# Altium schematic sheet analog-to-digital.SchDoc
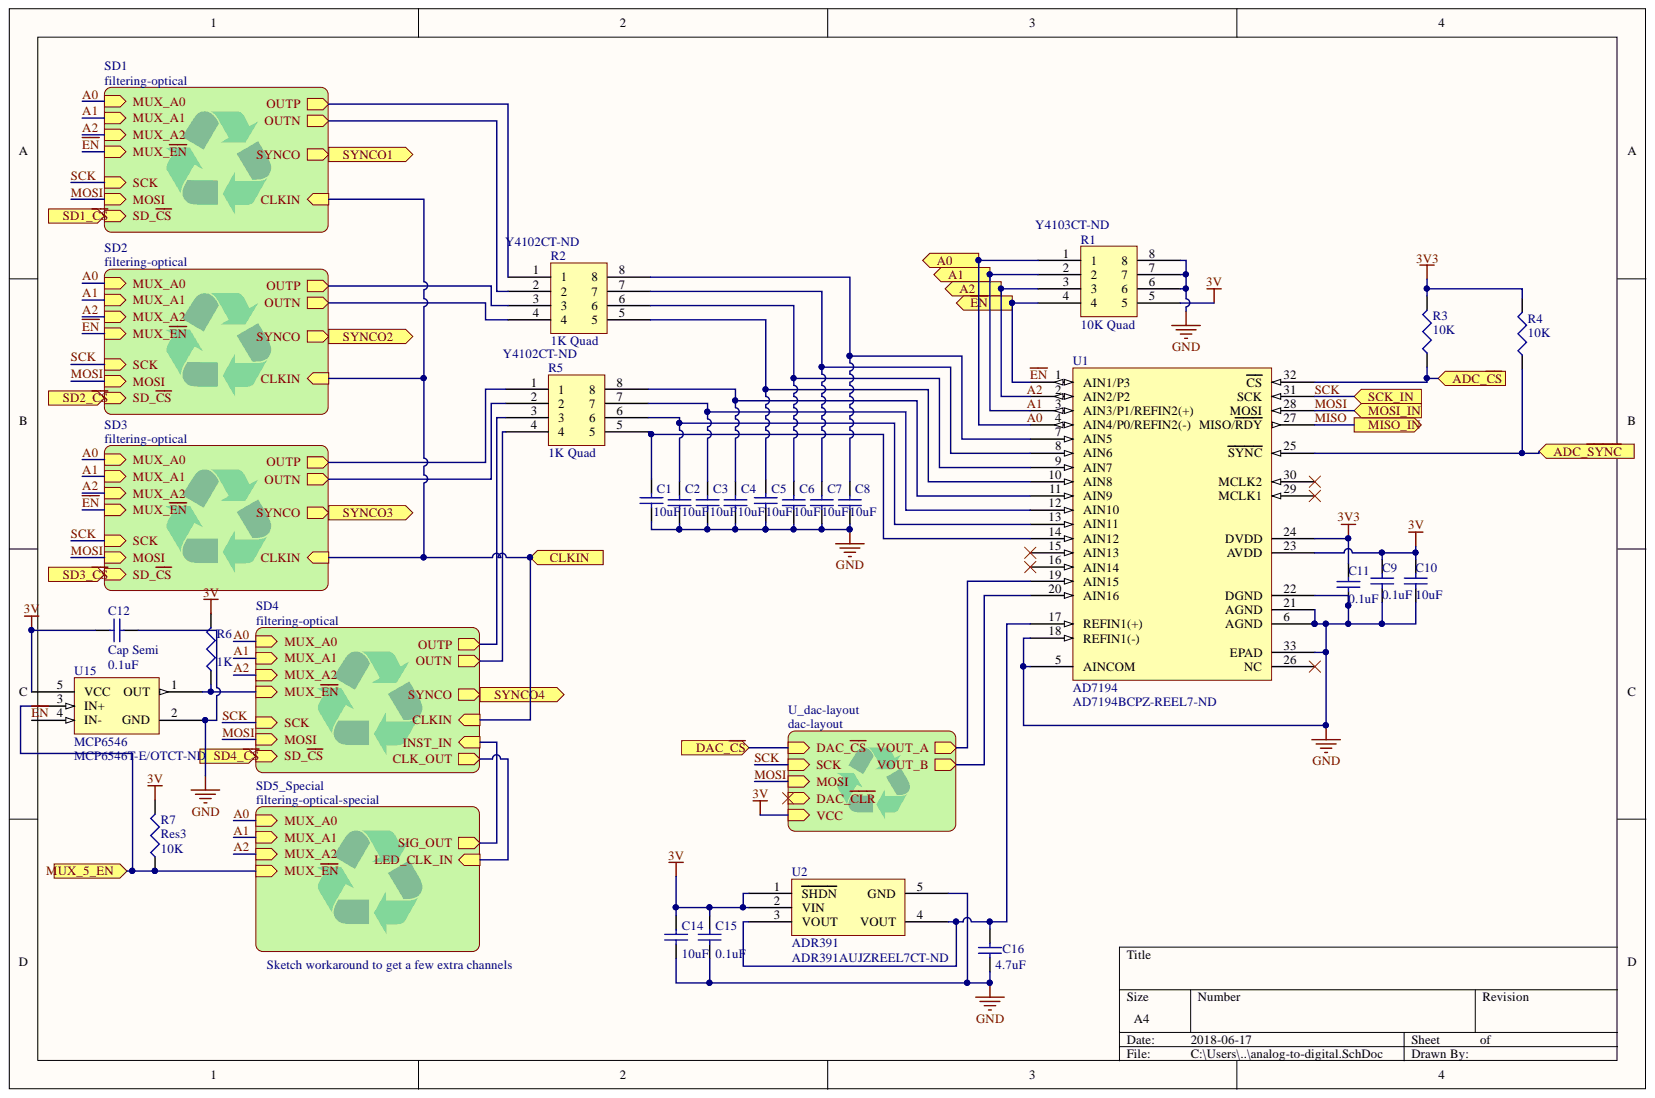
[diagram p0: analog-to-digital.SchDoc]

## Parts
C1  10uF  [1608[0603]]
C2  10uF  [1608[0603]]
C3  10uF  [1608[0603]]
C4  10uF  [1608[0603]]
C5  10uF  [1608[0603]]
C6  10uF  [1608[0603]]
C7  10uF  [1608[0603]]
C8  10uF  [1608[0603]]
C9  0.1uF  [0402]
C10  10uF  [1608[0603]]
C11  0.1uF  [0402]
C12  Cap Semi  value 0.1uF  [0402]
C14  10uF  [1608[0603]]
C15  0.1uF  [0402]
C16  4.7uF  [1608[0603]]
R1  10K Quad  Supplier Part Number: Y4103CT-ND  [ResQuad-320X150]
R2  1K Quad  Supplier Part Number: Y4102CT-ND  [ResQuad-320X150]
R3  10K  [0402]
R4  10K  [0402]
R5  1K Quad  Supplier Part Number: Y4102CT-ND  [ResQuad-320X150]
R6  value 1K  [0402]  (hidden comment: Res3)
R7  Res3  value 10K  [0402]
U1  AD7194  Supplier Part Number 1: AD7194BCPZ-REEL7-ND  [QFN50P500X500X100-32N]
U2  ADR391  Supplier Part Number 1: ADR391AUJZREEL7CT-ND  [SOT-23-5_95P290X160-5N]
U15  MCP6546  Supplier Part Number 1: MCP6546T-E/OTCT-ND  [SOT-23-5_95P290X160-5N]

## Ports
A0, A1, A2, ADC_~{CS}, ADC_~{SYNC}, CLKIN, DAC_~{CS}, MISO_IN, MOSI_IN, MUX_5_EN, SCK_IN, SD1_~{CS}, SD2_~{CS}, SD3_~{CS}, SD4_~{CS}, SYNCO1, SYNCO2, SYNCO3, SYNCO4, ~{EN}

## Nets
3V: C9.2 C10.2 C12.2 C14.2 C15.2 R1.5 R6.2 R7.2 U1.23(AVDD) U2.1(SHDN) U2.2(VIN) U15.5(VCC)
3V3: C11.2 R3.2 R4.2 U1.24(DVDD)
GND: C1.1 C2.1 C3.1 C4.1 C5.1 C6.1 C7.1 C8.1 C9.1 C10.1 C11.1 C12.1 C14.1 C15.1 C16.1 R1.6 R1.7 R1.8 U1.5(AINCOM) U1.6(AGND) U1.18(REFIN1(-)) U1.21(AGND) U1.22(DGND) U1.33(EPAD) U2.5(GND) U15.2(GND)
A0: R1.1 U1.4(AIN4/P0/REFIN2(-)) ; port A0
A1: R1.2 U1.3(AIN3/P1/REFIN2(+)) ; port A1
A2: R1.3 U1.2(AIN2/P2) ; port A2
ADC_~{CS}: R3.1 U1.32(CS) ; port ADC_~{CS}
ADC_~{SYNC}: R4.1 U1.25(SYNC) ; port ADC_~{SYNC}
~{EN}: R1.4 U1.1(AIN1/P3) U15.4(IN-) ; port ~{EN}
MISO: U1.27(MISO/RDY) ; port MISO_IN
MOSI: U1.28(MOSI) ; port MOSI_IN
MUX_5_EN: R7.1 U15.3(IN+) ; port MUX_5_EN
SCK: U1.31(SCK) ; port SCK_IN
NetC1_2: C1.2 R5.5 U1.14(AIN12)
NetC2_2: C2.2 R5.6 U1.13(AIN11)
NetC3_2: C3.2 R5.7 U1.12(AIN10)
NetC4_2: C4.2 R5.8 U1.11(AIN9)
NetC5_2: C5.2 R2.5 U1.10(AIN8)
NetC6_2: C6.2 R2.6 U1.9(AIN7)
NetC7_2: C7.2 R2.7 U1.8(AIN6)
NetC8_2: C8.2 R2.8 U1.7(AIN5)
NetC16_2: C16.2 U1.17(REFIN1(+)) U2.3(VOUT) U2.4(VOUT)
NetR2_1: R2.1
NetR2_2: R2.2
NetR2_3: R2.3
NetR2_4: R2.4
NetR5_1: R5.1
NetR5_2: R5.2
NetR5_3: R5.3
NetR5_4: R5.4
NetR6_1: R6.1 U15.1(OUT)
N00111:
N00112: ; port DAC_~{CS}
N00113:
N00114:
N00115: ; port SYNCO4
N00116: ; port SYNCO3
N00117: ; port SYNCO2
N00118: ; port CLKIN
N00119: ; port SYNCO1
N00120: ; port SD4_~{CS}
N00121: ; port SD3_~{CS}
N00122: ; port SD2_~{CS}
N00123: ; port SD1_~{CS}

Not connected: U1 15(AIN13) 16(AIN14) 19(AIN15) 20(AIN16) 26(NC) 29(MCLK1) 30(MCLK2)

## Library data of the parts
C1-C11 C14-C16 = Cap Semi: Capacitor (Semiconductor SIM Model); Component Kind: Standard; LatestRevisionDate: 29-May-2009; LatestRevisionNote: IPC-7351 Footprint Added.; Library Name: Miscellaneous Devices.IntLib; PackageDescription: Chip Capacitor; PackageReference: C1206; Published: 8-Jun-2000; Signal Integrity: Cap Semi; Simulation: CAP; Supplier: Digi-Key; Publisher: Altium Limited
  C1-C8 C10 C14: Value: 10uF
  C9 C11 C15: Value: 0.1uF
  C16: Value: 4.7uF
C12 = Cap Semi: Capacitor (Semiconductor SIM Model); Value: 0.1uF; Component Kind: Standard; LatestRevisionDate: 29-May-2009; LatestRevisionNote: IPC-7351 Footprint Added.; Library Name: Miscellaneous Devices.IntLib; PackageDescription: Chip Capacitor; PackageReference: C1206; Published: 8-Jun-2000; Signal Integrity: Cap Semi; Simulation: CAP; Publisher: Altium Limited
R1 R2 R5 = Res Quad Pack: Quad pack resistor; Component Kind: Standard; Supplier: Digi-Key; Library Name: PAY.SchLib
  R1: Value: 10K Quad
  R2 R5: Value: 1K Quad
R3 R4 R6 R7 = Res3: Resistor; Component Kind: Standard; LatestRevisionDate: 29-May-2009; LatestRevisionNote: IPC-7351 Footprint Added.; Library Name: Miscellaneous Devices.IntLib; PackageDescription: Chip Resistor; PackageReference: J1-0603; Published: 8-Jun-2000; Signal Integrity: Res; Simulation: RESISTOR; Publisher: Altium Limited
  R3 R4: Supplier: Digi-Key; Value: 10K
U1 = AD7194: 24-bit sigma-delta ADC; Component Kind: Standard; Supplier: Digi-Key; Supplier 1: Digi-Key; Library Name: PAY.SchLib
U2 = ADR391: 2.5V reference voltage.; Component Kind: Standard; Supplier: Digi-Key; Supplier 1: Digi-Key; Library Name: PAY.SchLib
U15 = MCP6546: Open-drain output sub-microamp comparator.; Component Kind: Standard; Supplier 1: Digi-Key; Library Name: PAY.SchLib
